# Altium schematic sheet Shutdown.SchDoc(Shutdown)
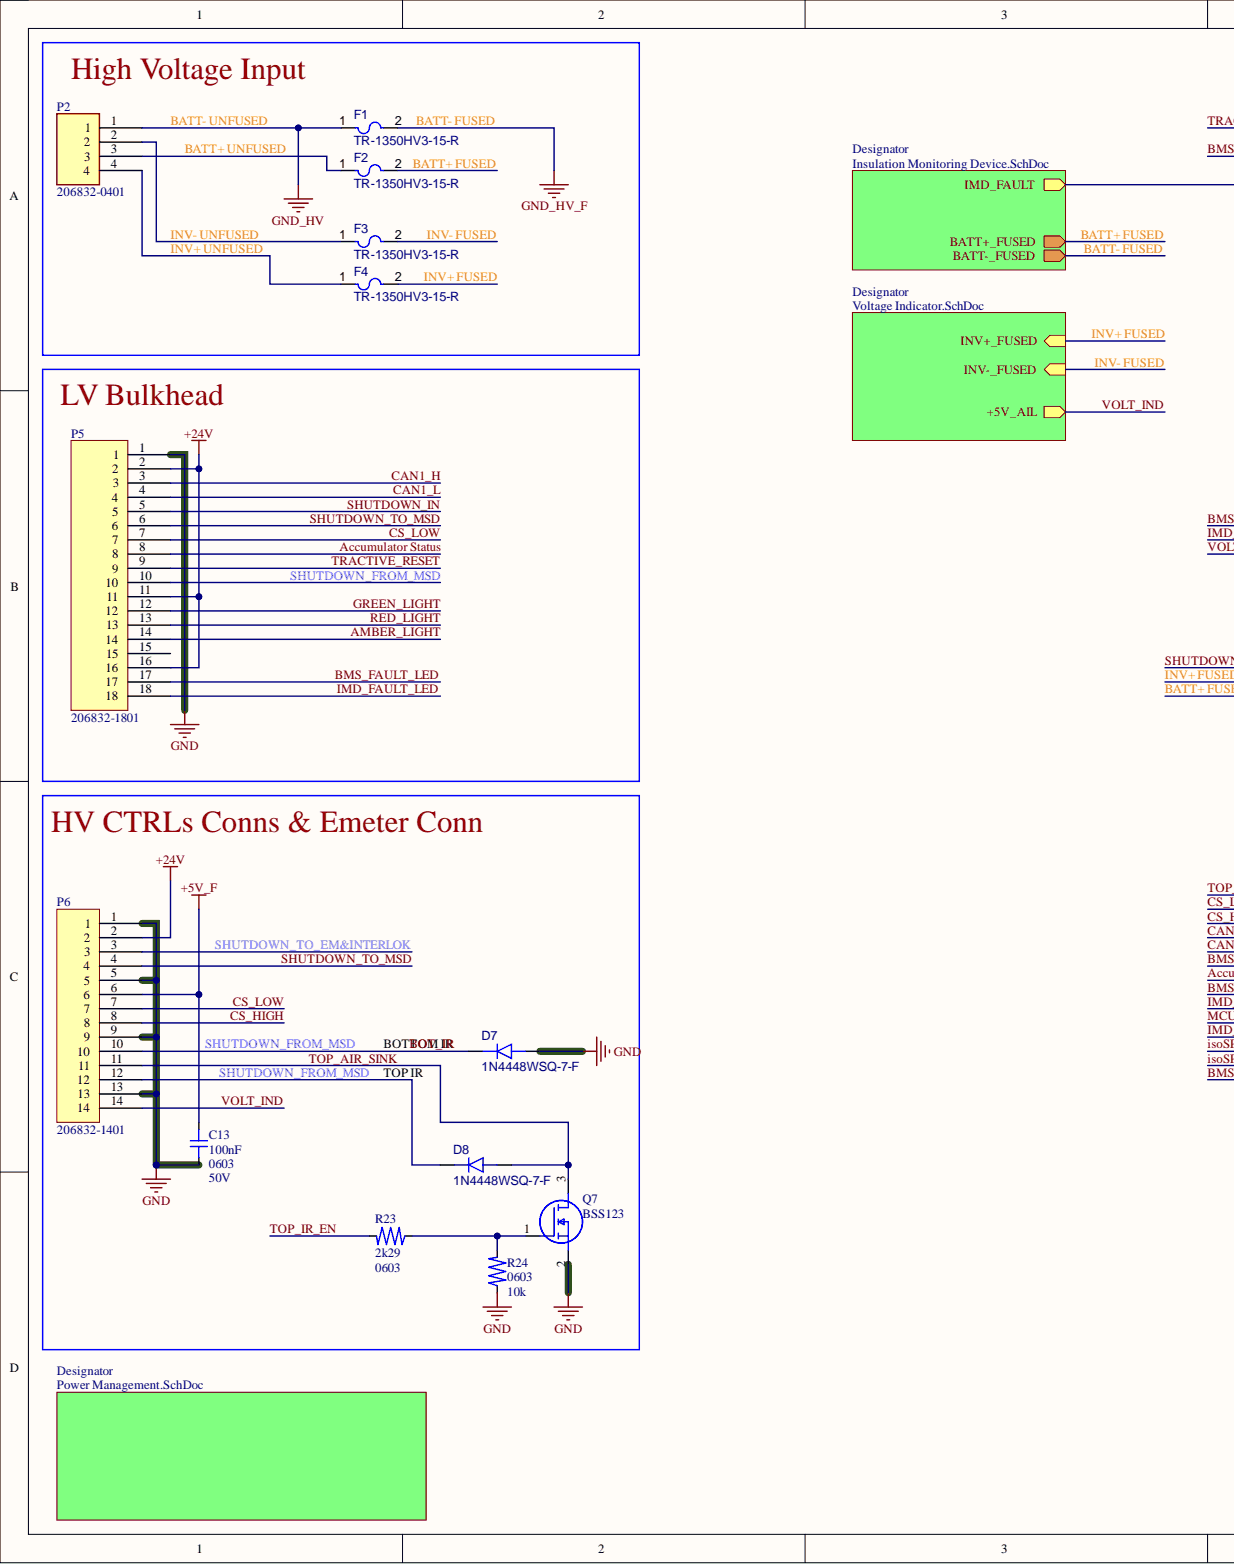
[diagram p0: Shutdown.SchDoc, left]
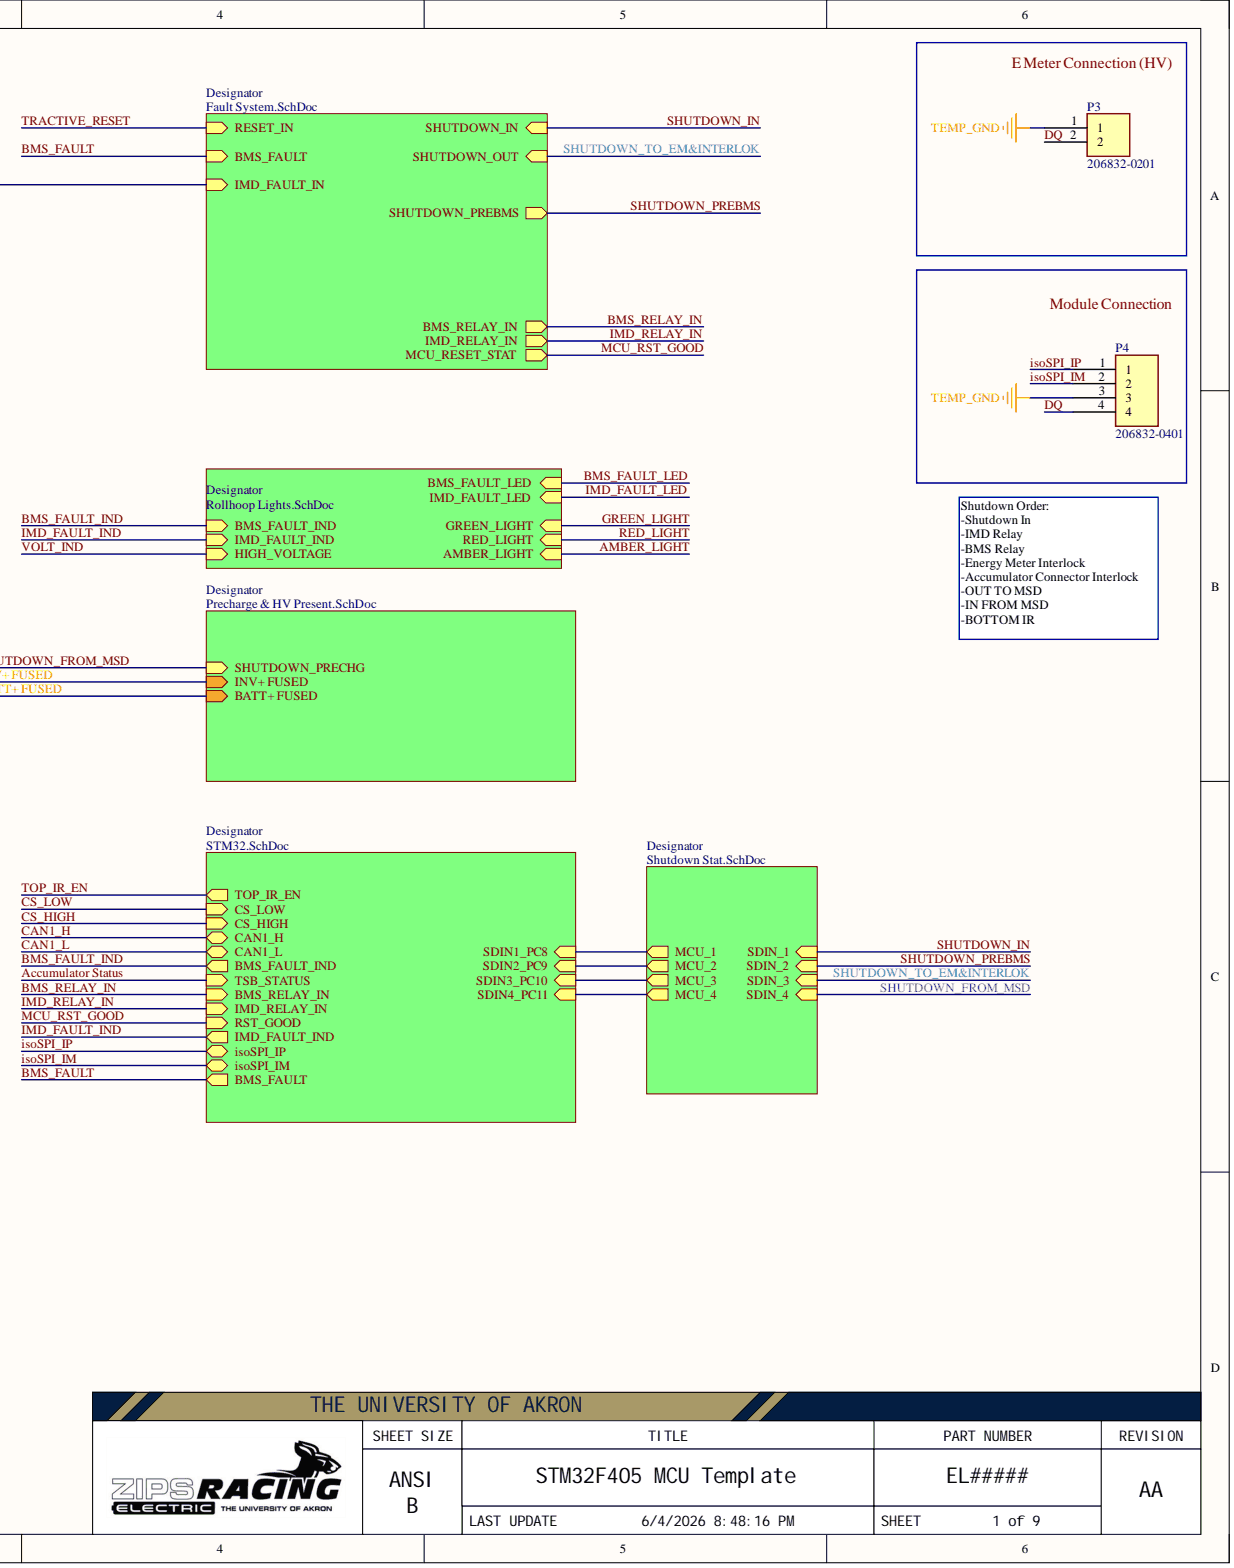
[diagram p1: Shutdown.SchDoc, right]

## Parts
C13  value 100nF  Voltage: 50V  [0603]  (hidden comment: Capacitor 100nF 0603 5%)
D7  1N4448WSQ-7-F
D8  1N4448WSQ-7-F
F1  TR-1350HV3-15-R
F2  TR-1350HV3-15-R
F3  TR-1350HV3-15-R
F4  TR-1350HV3-15-R
P2  206832-0401
P3  206832-0201
P4  206832-0401
P5  206832-1801
P6  206832-1401
Q7  BSS123
R23  value 2k29  [0603]  (hidden comment: Resistor 2k49 0603 1%)
R24  value 10k  [0603]  (hidden comment: Resistor 10k 0603 1%)

## Nets
+5V_F: C13.2 P6.6
+24V: P5.2 P5.11 P5.16 P6.2
GND: C13.1 D7.2 P5.1 P6.1 P6.5 P6.9 P6.13 Q7.2 R24.1
TEMP_GND: P3.1 P4.3
Accumulator Status: P5.8
AMBER_LIGHT: P5.14
BATT+ FUSED: F2.2
BATT+ UNFUSED: F2.1 P2.3
BATT- FUSED: F1.2
BATT- UNFUSED: F1.1 P2.1
BMS_FAULT_LED: P5.17
BOT_IR: D7.1 D8.1 P5.10 P6.10 P6.12
CAN1_H: P5.3
CAN1_L: P5.4
CS_HIGH: P6.8
CS_LOW: P5.7 P6.7
DQ: P3.2 P4.4
GREEN_LIGHT: P5.12
IMD_FAULT_LED: P5.18
INV+ FUSED: F4.2
INV+ UNFUSED: F4.1 P2.4
INV- FUSED: F3.2
INV- UNFUSED: F3.1 P2.2
isoSPI_IM: P4.2
isoSPI_IP: P4.1
RED_LIGHT: P5.13
SHUTDOWN_IN: P5.5
SHUTDOWN_TO_EM&INTERLOK: P6.3
SHUTDOWN_TO_MSD: P5.6 P6.4
TOP_AIR_SINK: D8.2 P6.11 Q7.3
TOP_IR_EN: R23.2
TRACTIVE_RESET: P5.9
VOLT_IND: P6.14
NetP5_15: P5.15
NetQ7_1: Q7.1 R23.1 R24.2
BMS_FAULT:
BMS_FAULT_IND:
BMS_RELAY_IN:
IMD_FAULT:
IMD_FAULT_IND:
IMD_RELAY_IN:
MCU_1:
MCU_2:
MCU_3:
MCU_4:
MCU_RST_GOOD:
SHUTDOWN_PREBMS:

## Library data of the parts
C13 = CMP-00030-2: Capacitor, 0603, 5%; Component Kind: Standard; Max Operating Temperature: 125°C; Min Operating Temperature: -55°C; Library Name: Zips Racing Electric
D7 D8 = CMP-004-00080-1: Rectifier Diode, 1 Phase, 1 Element, 0.25A, 75V V(RRM), Silicon; Component Kind: Standard; Max Operating Temperature: 150°C; Min Operating Temperature: -65°C; Mounting Technology: Surface Mount; Library Name: Zips Racing Electric
F1-F4 = CMP-005-00024-1: Electric Fuse, Fast Blow, 3.15A, 350VAC, 600VDC, 100A (IR), Surface Mount; Component Kind: Standard; Current Rating: 3.15A; Max Operating Temperature: 125°C; Min Operating Temperature: -40°C; Mounting Technology: Surface Mount; Library Name: Zips Racing Electric
P2 P4 = CMP-002-00114-6: Micro-Fit+ Vertical Header, Through Hole, 3.00mm Pitch, Dual Row, 4 Circuits, Tin (Sn) Plating, Glow-Wire Capable, Black, Tray; Connector Type: PCB Header; Gender: Male; Mating Cycls: 25; Max Current Rating: 12.5A; Rows: 2; Voltage Rating: 600V; Component Kind: Standard; ComponentLink1Description: Datasheet; ComponentLink1URL: https://www.molex.com/en-us/products/part-detail/2068320401?display=pdf; Max Operating Temperature: 105°C; Min Operating Temperature: -40°C; Mounting Technology: Thru-hole; Pins: 4; Plating Material: Tin; Library Name: Zips Racing Electric
P3 = CMP-002-00123-3: Molex, Micro-Fit+, 2 Position, Dual-Row, Header, Male, 3mm Pitch; Max Current Rating: 13A; Voltage Rating: 600V; Component Kind: Standard; Library Name: Zips Racing Electric
P5 = CMP-002-00147-2: Micro-Fit+ Vertical Header, Through Hole, 3.00mm Pitch, Dual Row, 16 Circuits, 0.38µm Gold (Au) Plating, Glow-Wire Capable, Black, Tray; Connector Type: PCB Header; Gender: Female; Mating Cylces: 25; Max Current Rating: 12A; Plating Material: Tin; Voltage Rating: 600V; Component Kind: Standard; ComponentLink1Description: Datasheet; ComponentLink1URL: https://www.molex.com/en-us/products/part-detail/2068321601?display=pdf; Max Operating Temperature: 105°C; Min Operating Temperature: -40°C; Mounting Technology: Thru-hole; Orientation: Vertical; Pins: 16; Library Name: Zips Racing Electric
P6 = CMP-002-00137-1: MICRO-FIT Plus Header Assembly Dual Row 10 Position Straight Tin; Component Kind: Standard; Library Name: Zips Racing Electric
Q7 = CMP-023-000002-1: MOSFET N-CH 100V 170MA SOT23-3; Manufacturer_Name: ON Semiconductor; Arrow Part Number: BSS123; Arrow Price/Stock: https://www.arrow.com/en/products/bss123/on-semiconductor; Component Kind: Standard; Datasheet Link: http://uk.rs-online.com/web/p/products/6710321; Design Item ID: BSS123; Height: 1.11mm; Manufacturer_Part_Number: BSS123; Mouser Part Number: 512-BSS123; Mouser Price/Stock: https://www.mouser.co.uk/ProductDetail/ON-Semiconductor-Fairchild/BSS123?qs=FOlmdCx%252BAA2Kf8SEB3qLYA%3D%3D; Library Name: Zips Racing Electric; Source: Zips_Racing_Electric.SchLib
R23 = CMP-00028-1: Resistor, 0603, 1%, 1/10W; Power: 100mW; Component Kind: Standard; Datasheet: https://www.seielect.com/catalog/sei-rmcf_rmcp.pdf; Max Operating Temperature: 155°C; Min Operating Temperature: -55°C; Tolerance: 1%; Library Name: Zips Racing Electric
R24 = CMP-00020-12: Resistor, 0603, 10k; Power: 100mW; Component Kind: Standard; Datasheet: https://www.seielect.com/catalog/sei-rmcf_rmcp.pdf; Max Operating Temperature: 155°C; Maximum Operating Temperature: 155°C; Min Operating Temperature: -55°C; Minimum Operating Temperature: -55°C; Tolerance: 1%; Library Name: Zips Racing Electric
